# Altium schematic sheet SEM_tree.SchDoc
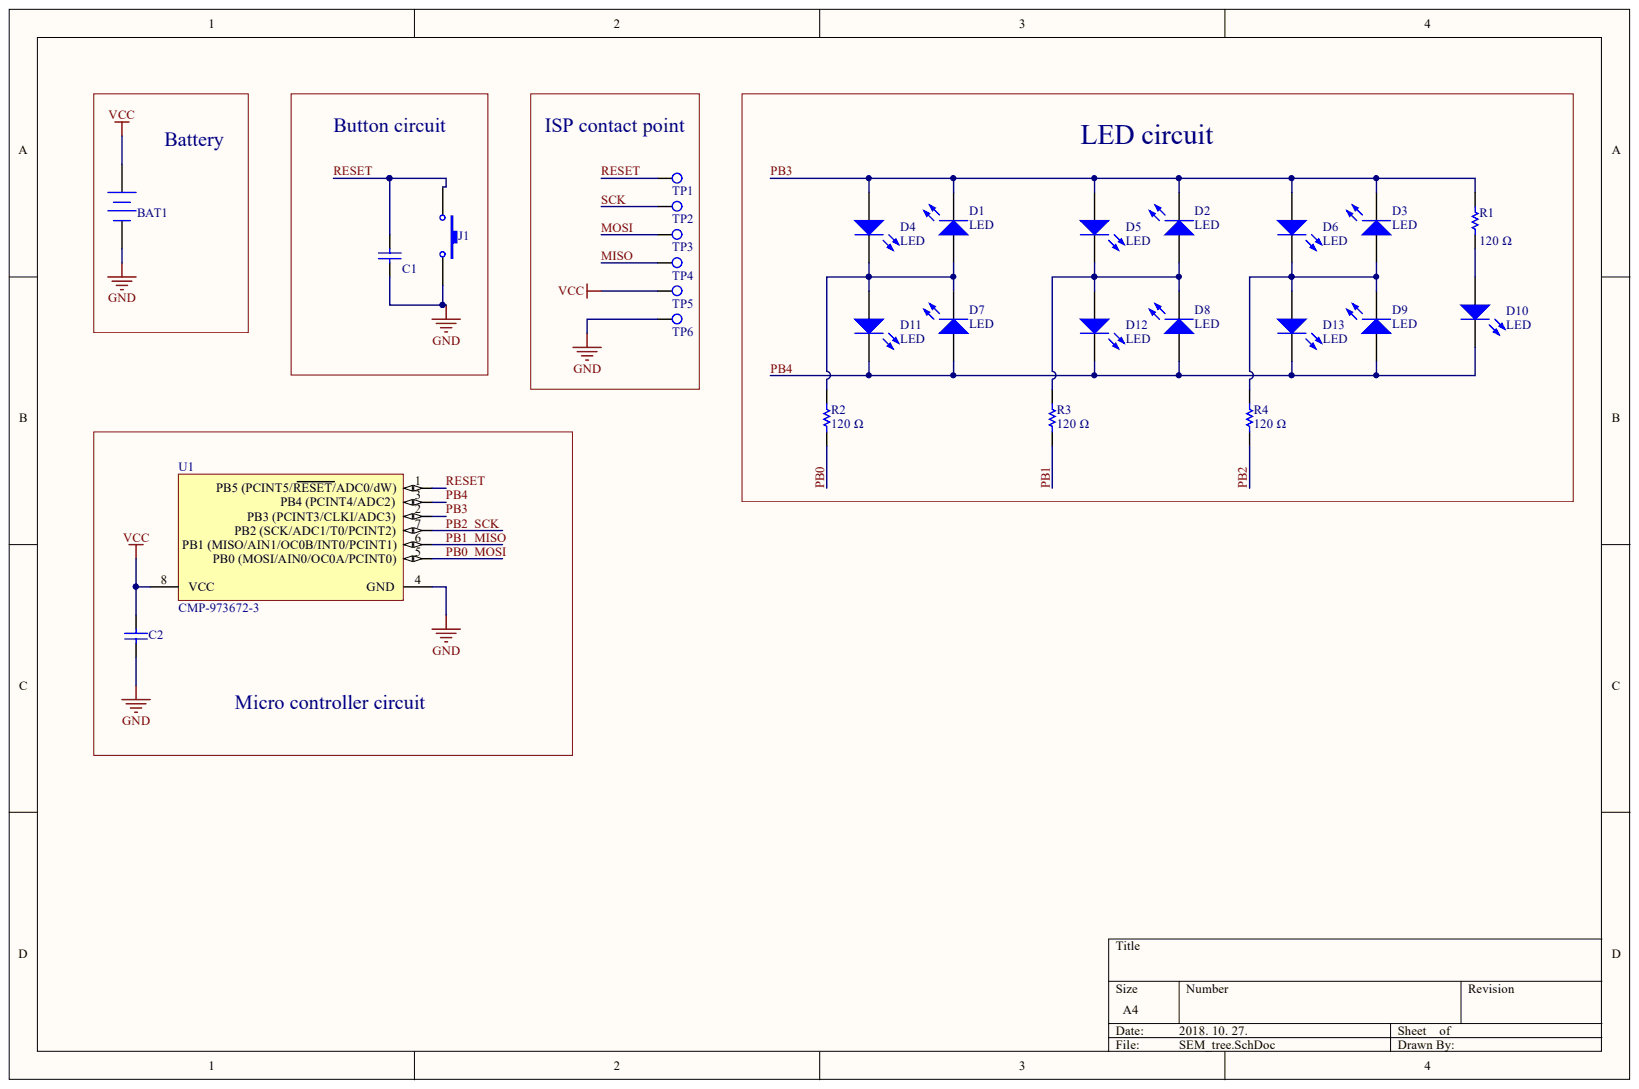
[diagram p0: SEM_tree.SchDoc]

## Parts
BAT1  Keystone 106  [PCB-ohc0a4v9ltwuu42wbzu0-1]  (value not drawn)
C1  YAGEO CC0805KKX7R8BB105  [C0805]  (value not drawn)
C2  YAGEO CC0805KKX7R8BB105  [C0805]  (value not drawn)
D1  LED  [PCB-vy9cus42al8cyunz52cf-1]
D2  LED  [PCB-vy9cus42al8cyunz52cf-1]
D3  LED  [PCB-vy9cus42al8cyunz52cf-1]
D4  LED  [PCB-vy9cus42al8cyunz52cf-1]
D5  LED  [PCB-vy9cus42al8cyunz52cf-1]
D6  LED  [PCB-vy9cus42al8cyunz52cf-1]
D7  LED  [PCB-vy9cus42al8cyunz52cf-1]
D8  LED  [PCB-vy9cus42al8cyunz52cf-1]
D9  LED  [PCB-vy9cus42al8cyunz52cf-1]
D10  LED  [PCB-vy9cus42al8cyunz52cf-1]
D11  LED  [PCB-vy9cus42al8cyunz52cf-1]
D12  LED  [PCB-vy9cus42al8cyunz52cf-1]
D13  LED  [PCB-vy9cus42al8cyunz52cf-1]
J1  SYM-2e78e514dd61f1bf-1  [Switch]  (value not drawn)
R1  Resistance: 120 Ω  [PCB-4097432-1]  (hidden comment: Panasonic ERA6AEB2492V)
R2  Resistance: 120 Ω  [PCB-4097432-1]  (hidden comment: Panasonic ERA6AEB2492V)
R3  Resistance: 120 Ω  [PCB-4097432-1]  (hidden comment: Panasonic ERA6AEB2492V)
R4  Resistance: 120 Ω  [PCB-4097432-1]  (hidden comment: Panasonic ERA6AEB2492V)
TP1  Test Point Pad  [PCB-2eo10sxl6e9w4kr03i1b-1]  (value not drawn)
TP2  Test Point Pad  [PCB-2eo10sxl6e9w4kr03i1b-1]  (value not drawn)
TP3  Test Point Pad  [PCB-2eo10sxl6e9w4kr03i1b-1]  (value not drawn)
TP4  Test Point Pad  [PCB-2eo10sxl6e9w4kr03i1b-1]  (value not drawn)
TP5  Test Point Pad  [PCB-2eo10sxl6e9w4kr03i1b-1]  (value not drawn)
TP6  Test Point Pad  [PCB-2eo10sxl6e9w4kr03i1b-1]  (value not drawn)
U1  CMP-973672-3  [8S2_N]

## Nets
GND: BAT1.2 C1.1 C2.2 J1.2 TP6.1 U1.4(GND)
VCC: BAT1.1 C2.1 TP5.1 U1.8(VCC)
MISO: R3.1 TP4.1 U1.6(PB1_(MISO/AIN1/OC0B/INT0/PCINT1))
MOSI: R2.1 TP3.1 U1.5(PB0_(MOSI/AIN0/OC0A/PCINT0))
PB2: R4.1 TP2.1 U1.7(PB2_(SCK/ADC1/T0/PCINT2))
PB3: D1.2 D2.2 D3.2 D4.1 D5.1 D6.1 R1.2 U1.2(PB3_(PCINT3/CLKI/ADC3))
PB4: D7.1 D8.1 D9.1 D10.2 D11.2 D12.2 D13.2 U1.3(PB4_(PCINT4/ADC2))
RESET: C1.2 J1.1 TP1.1 U1.1(PB5_(PCINT5/RESET/ADC0/dW))
NetD1_1: D1.1 D4.2 D7.2 D11.1 R2.2
NetD2_1: D2.1 D5.2 D8.2 D12.1 R3.2
NetD3_1: D3.1 D6.2 D9.2 D13.1 R4.2
NetD10_1: D10.1 R1.1

## Library data of the parts
BAT1 = CMP-01ddc8d7806dde1a-1: Term Blk; Barrier; Hvy Dty Dbl Rw; 6 Pole; 20 AMPS; 250; ; Kulka 670AGP06; Manufacturer Part Number: 106; Lead-Free Status: Lead Free; Component Kind: Standard; Contact Plating: Nickel; Library Name: CommunityVault; Mounting Style: PC Board, Through Hole, PCB; Octopart URL: https://octopart.com/106-keystone-42198; REACH SVHC Compliance: No SVHC; RoHS: Compliant; Size-Diameter: 20.0 mm
C1 C2 = CMP-77c3bc4dc880a804-3: 0805 1 uF 25 V ±10 % Tolerance X7R SMT Multilayer Ceramic Capacitor; Capacitance: 1uF; Capacitance Tolerance: ±10%; Lead-Free Status: Lead Free; Voltage Rating (DC): 25.0 V; Case/Package: 0805; Component Kind: Standard; Dielectric Characteristic: X7R; Halogen Free Status: Halogen Free; Library Name: CommunityVault; Manufacturer Part Number: CC0805KKX7R8BB105; Mounting Style: Surface Mount; Number of Pins: 2; OctopartID: 77c3bc4dc880a804; Octopart Url: http://octopart.com/cc0805kkx7r8bb105-yageo-42244645; Packaging: Cut Tape (CT); REACH SVHC Compliance: No SVHC; RoHS: Compliant; Seller Landing  Url: https://octopart-clicks.com/click/track?country=US&ak=8157f166&sig=04b9cde&sid=5489&ppid=42244645&vpid=127579773&ct=offers
D1-D13 = SML-210LTT86: ROHM SML-210LTT86, SML-21 Series Red LED, 2012(0805), Rectangle Lens SMD package; Forward Voltage: 1.75 V; Lens Color: Transparent; Luminous Intensity: 10.0 mcd; Viewing Angle: 140 °; Case/Package: 0805; Color: Red; Component Kind: Standard; Library Name: Octopart library; OctopartID: 04e5cde746c4db22; Size-Width: 1.25 mm
J1 = CMP-2e78e514dd61f1bf-2: Switch Tactile OFF (ON) SPST Rectangular Button Gull Wing 0.05A 12VDC 1.77N SMD T/R; Manufacturer Part Number: FSMSMTR; ELV Compliant: Compliant; Lead-Free Status: Lead Free; Component Kind: Standard; Contact Material: Brass; Contact Plating: Silver; Contacts Type: SPST; Library Name: CommunityVault; Lifecycle Status: Active; Mounting Style: Surface Mount; RoHS: Compliant
R1-R4 = CMP-2526625-3: RESISTOR, 0805, 24K9, 0.1%, 0.125W; Manufacturer Part Number: ERA-6AEB2492V; Voltage Rating (DC): 100.0 V; Component Kind: Standard; Library Name: CommunityVault; Lifecycle Status: Not Listed by Manufacturer; Mounting Style: Surface Mount; Number of Pins: 2; OctopartID: c29e091ada021d59; Octopart Url: https://octopart.com/era6aeb2492v-panasonic-42876410; Packaging: Tape & Reel (TR); Power Rating: 0.125 W; REACH SVHC Compliance: No SVHC; Resistance Tolerance: ±0.1%; RoHS: Compliant
TP1-TP6 = CMP-0dc8c4c22640d20f-13: Component Kind: Standard; Description: Test Point Pad; Library Name: CommunityVault
U1 = CMP-973672-3: 8-bit AVR Microcontroller, 1.8-5.5V, 20MHz, 1KB Flash, 64 Bytes EEPROM, 64 Bytes SRAM, 8-pin SOIC, Industrial Grade (-40°C to 85°C), Pb-Free; Manufacturer Part Number: ATTINY13A-SU; Clock Speed: 20000000.0 Hz; FLASH Memory Size: 1024 B; Lead-Free Status: Lead Free; RAM Memory Size: 64 B; Component Kind: Standard; Library Name: CommunityVault; Number of Pins: 8; OctopartID: 893440b1a67b56f3; Octopart Url: https://octopart.com/attiny13a-su-atmel-9374249; REACH SVHC Compliance: No SVHC; RoHS: Compliant
